# Altium schematic sheet MCU.SchDoc
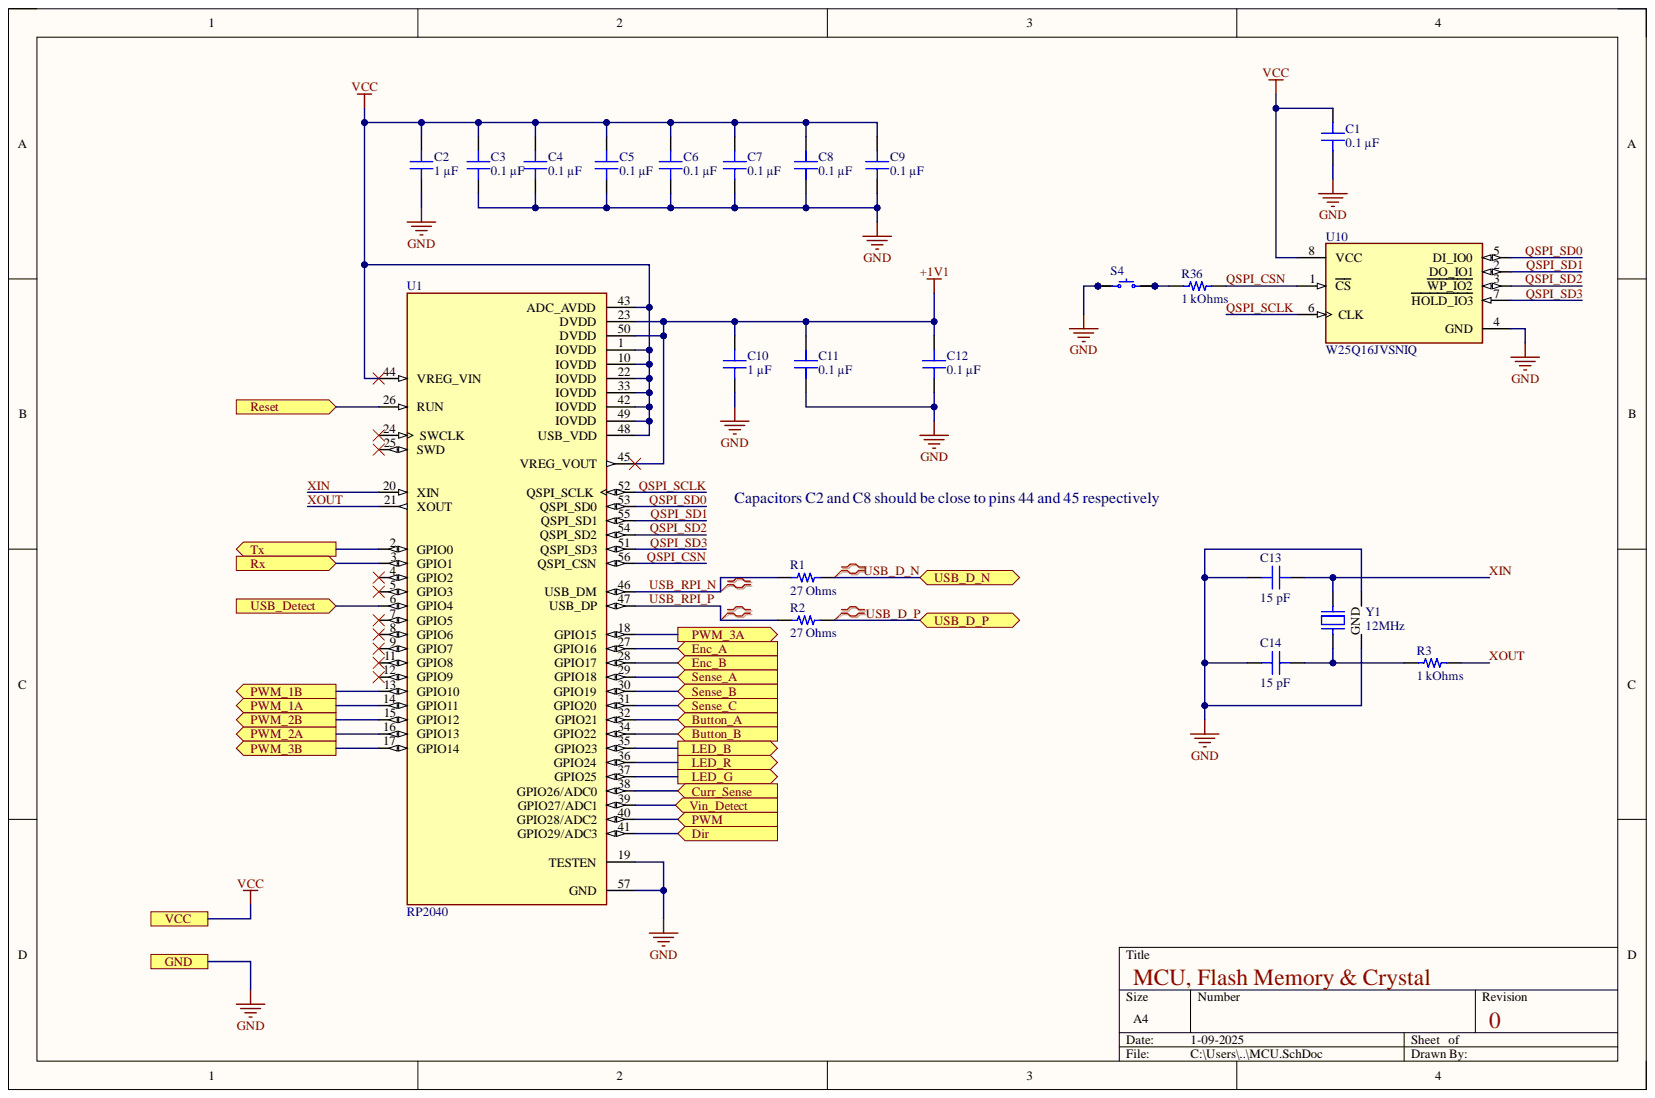
[diagram p0: MCU.SchDoc]

## Parts
C1  0.1 µF  [CAP 0201_0603]
C2  1 µF  [CAP 0201_0603]
C3  0.1 µF  [CAP 0201_0603]
C4  0.1 µF  [CAP 0201_0603]
C5  0.1 µF  [CAP 0201_0603]
C6  0.1 µF  [CAP 0201_0603]
C7  0.1 µF  [CAP 0201_0603]
C8  0.1 µF  [CAP 0201_0603]
C9  0.1 µF  [CAP 0201_0603]
C10  1 µF  [CAP 0201_0603]
C11  0.1 µF  [CAP 0201_0603]
C12  0.1 µF  [CAP 0201_0603]
C13  15 pF  [CAP 0201_0603]
C14  15 pF  [CAP 0201_0603]
R1  27 Ohms  [YAGEO RES 0201_0603]
R2  27 Ohms  [YAGEO RES 0201_0603]
R3  1 kOhms  [YAGEO RES 0201_0603]
R36  1 kOhms  [YAGEO RES 0201_0603]
S4  KMR741NG ULC LFS  [C&K KMR7]  (value not drawn)
U1  RP2040  [QFN40P700X700X90-57N]
U10  W25Q16JVSNIQ  [FP-W25Q16JVSNIQ_TR-IPC_B]
Y1  12MHz  [ABRACON ABM8G]

## Ports
Button_A, Button_B, Curr_Sense, Dir, Enc_A, Enc_B, GND, LED_B, LED_G, LED_R, PWM, PWM_1A, PWM_1B, PWM_2A, PWM_2B, PWM_3A, PWM_3B, Reset, Rx, Sense_A, Sense_B, Sense_C, Tx, USB_D_N, USB_D_P, USB_Detect, VCC, Vin_Detect

## Nets
+1V1: C10.2 C11.2 C12.2 U1.23(DVDD) U1.45(VREG_VOUT) U1.50(DVDD)
Button_A: U1.32(GPIO21) ; port Button_A
Button_B: U1.34(GPIO22) ; port Button_B
Curr_Sense: U1.38(GPIO26/ADC0) ; port Curr_Sense
Dir: U1.41(GPIO29/ADC3) ; port Dir
Enc_A: U1.27(GPIO16) ; port Enc_A
Enc_B: U1.28(GPIO17) ; port Enc_B
GND: C1.1 C2.1 C3.1 C4.1 C5.1 C6.1 C7.1 C8.1 C9.1 C10.1 C11.1 C12.1 C13.1 C14.1 S4.1 S4.2 U1.19(TESTEN) U1.57(GND) U10.4(GND) Y1.2 Y1.4 ; port GND
LED_B: U1.35(GPIO23) ; port LED_B
LED_G: U1.37(GPIO25) ; port LED_G
LED_R: U1.36(GPIO24) ; port LED_R
PWM: U1.40(GPIO28/ADC2) ; port PWM
PWM_1A: U1.14(GPIO11) ; port PWM_1A
PWM_1B: U1.13(GPIO10) ; port PWM_1B
PWM_2A: U1.16(GPIO13) ; port PWM_2A
PWM_2B: U1.15(GPIO12) ; port PWM_2B
PWM_3A: U1.18(GPIO15) ; port PWM_3A
PWM_3B: U1.17(GPIO14) ; port PWM_3B
QSPI_CSN: R36.1 U1.56(QSPI_CSN) U10.1(CS)
QSPI_SCLK: U1.52(QSPI_SCLK) U10.6(CLK)
QSPI_SD0: U1.53(QSPI_SD0) U10.5(DI_IO0)
QSPI_SD1: U1.55(QSPI_SD1) U10.2(DO_IO1)
QSPI_SD2: U1.54(QSPI_SD2) U10.3(WP_IO2)
QSPI_SD3: U1.51(QSPI_SD3) U10.7(HOLD_IO3)
Reset: U1.26(RUN) ; port Reset
Rx: U1.3(GPIO1) ; port Rx
Sense_A: U1.29(GPIO18) ; port Sense_A
Sense_B: U1.30(GPIO19) ; port Sense_B
Sense_C: U1.31(GPIO20) ; port Sense_C
Tx: U1.2(GPIO0) ; port Tx
USB_D_N: R1.2 ; port USB_D_N
USB_D_P: R2.2 ; port USB_D_P
USB_Detect: U1.6(GPIO4) ; port USB_Detect
USB_RPI_N: R1.1 U1.46(USB_DM)
USB_RPI_P: R2.1 U1.47(USB_DP)
VCC: C1.2 C2.2 C3.2 C4.2 C5.2 C6.2 C7.2 C8.2 C9.2 U1.1(IOVDD) U1.10(IOVDD) U1.22(IOVDD) U1.33(IOVDD) U1.42(IOVDD) U1.43(ADC_AVDD) U1.44(VREG_VIN) U1.48(USB_VDD) U1.49(IOVDD) U10.8(VCC) ; port VCC
Vin_Detect: U1.39(GPIO27/ADC1) ; port Vin_Detect
XIN: C13.2 U1.20(XIN) Y1.3
XOUT: R3.2 U1.21(XOUT)
NetC14_2: C14.2 R3.1 Y1.1
NetR36_2: R36.2 S4.3 S4.4
NetU1_4: U1.4(GPIO2)
NetU1_5: U1.5(GPIO3)
NetU1_7: U1.7(GPIO5)
NetU1_8: U1.8(GPIO6)
NetU1_9: U1.9(GPIO7)
NetU1_11: U1.11(GPIO8)
NetU1_12: U1.12(GPIO9)
NetU1_24: U1.24(SWCLK)
NetU1_25: U1.25(SWD)

## Library data of the parts
C1 C3-C9 C11 C12 = 885012104003: CAP CER 0.1UF 6.3V X5R 0201; manufacturer: Würth Elektronik; Database Table Name: Capacitors - Ceramic - 0201; Tolerance: ±20%; Applications: General Purpose; Capacitance: 0.1 µF; Component Kind: Standard; componentlink1description: Datasheet; componentlink1url: https://www.we-online.com/katalog/datasheet/885012104003.pdf; componentlink2description: DigiKey Link; componentlink2url: https://www.digikey.com/en/products/detail/würth-elektronik/885012104003/17734056; Device Package: 0201 (0603 Metric); lastupdated: 04-06-2024 19:00:59; Library Name: Celestial Altium Library - altium_library.DbLib; Lifecycle Status: Active; Minimum Order: 1; Mounting Type: Surface Mount, MLCC; Operating Temperature: -55°C ~ 85°C; Package / Case: 0201 (0603 Metric); packaging: TapeAndReel; Part Number: 885012104003; Part Status: Active; partid: 47467; price: 0.01; series: WCAP-CSGP; Size / Dimension: 0.024" L x 0.012" W (0.60mm x 0.30mm); Supplier 1: DigiKey; Supplier Part Number 1: 732-885012104003CT-ND; Temperature Coefficient: X5R; Thickness (Max): 0.013" (0.33mm); Value: 0.1 µF; Voltage - Rated: 6.3V
C2 C10 = 885012104011: CAP CER 1UF 6.3V X5R 0201; manufacturer: Würth Elektronik; Database Table Name: Capacitors - Ceramic - 0201; Tolerance: ±20%; Applications: General Purpose; Capacitance: 1 µF; Component Kind: Standard; componentlink1description: Datasheet; componentlink1url: https://www.we-online.com/katalog/datasheet/885012104011.pdf; componentlink2description: DigiKey Link; componentlink2url: https://www.digikey.com/en/products/detail/würth-elektronik/885012104011/17734031; Device Package: 0201 (0603 Metric); lastupdated: 04-06-2024 19:00:59; Library Name: Celestial Altium Library - altium_library.DbLib; Lifecycle Status: Active; Minimum Order: 1; Mounting Type: Surface Mount, MLCC; Operating Temperature: -55°C ~ 85°C; Package / Case: 0201 (0603 Metric); packaging: TapeAndReel; Part Number: 885012104011; Part Status: Active; partid: 47474; price: 0.01; series: WCAP-CSGP; Size / Dimension: 0.024" L x 0.012" W (0.60mm x 0.30mm); Supplier 1: DigiKey; Supplier Part Number 1: 732-885012104011CT-ND; Temperature Coefficient: X5R; Thickness (Max): 0.015" (0.39mm); Value: 1 µF; Voltage - Rated: 6.3V
C13 C14 = 885012004006: CAP CER 15PF 25V C0G/NP0 0201; manufacturer: Würth Elektronik; Database Table Name: Capacitors - Ceramic - 0201; Temperature Coefficient: C0G, NP0; Tolerance: ±5%; Applications: General Purpose; Capacitance: 15 pF; Component Kind: Standard; componentlink1description: Datasheet; componentlink1url: https://www.we-online.com/katalog/datasheet/885012004006.pdf; componentlink2description: DigiKey Link; componentlink2url: https://www.digikey.com/en/products/detail/würth-elektronik/885012004006/17734188; Device Package: 0201 (0603 Metric); lastupdated: 04-06-2024 19:00:59; Library Name: Celestial Altium Library - altium_library.DbLib; Lifecycle Status: Active; Minimum Order: 1; Mounting Type: Surface Mount, MLCC; Operating Temperature: -55°C ~ 125°C; Package / Case: 0201 (0603 Metric); packaging: TapeAndReel; Part Number: 885012004006; Part Status: Active; partid: 47479; price: 0.01; series: WCAP-CSGP; Size / Dimension: 0.024" L x 0.012" W (0.60mm x 0.30mm); Supplier 1: DigiKey; Supplier Part Number 1: 732-885012004006CT-ND; Thickness (Max): 0.013" (0.33mm); Value: 15 pF; Voltage - Rated: 25V
R1 R2 = RC0201FR-0727RL: RES 27 OHM 1% 1/20W 0201; Manufacturer: Yageo; Database Table Name: Resistors - Surface Mount - 0201; Power (Watts): 0.05W, 1/20W; Temperature Coefficient: ±200ppm/°C; Component Kind: Standard; ComponentLink1Description: Datasheet; ComponentLink1URL: https://www.yageo.com/upload/media/product/productsearch/datasheet/rchip/PYu-RC_Group_51_RoHS_L_10.pdf; ComponentLink2Description: DigiKey Link; ComponentLink2URL: https://www.digikey.com/en/products/detail/yageo/RC0201FR-0727RL/5280414; Composition: Thick Film; Device Package: 0201 (0603 Metric); Features: Moisture Resistant; Height - Seated (Max): 0.010" (0.26mm); LastUpdated: 25-07-2024 09:16:22; Library Name: Celestial Altium Library - altium_library.DbLib; Lifecycle Status: Active; Minimum Order: 1; Number of Terminations: 2; Operating Temperature: -55°C ~ 125°C; Package / Case: 0201 (0603 Metric); Packaging: TapeAndReel; Part Number: RC0201FR-0727RL; Part Status: Active; PartId: 3612; Price: 0.01; Resistance: 27 Ohms; Series: RC_L; Size / Dimension: 0.024" L x 0.012" W (0.60mm x 0.30mm); Supplier 1: DigiKey; Supplier Device Package: 0201; Supplier Part Number 1: YAG2554CT-ND; Tolerance: ±1%; Value: 27 Ohms
R3 = RC0201FR-131KL: RES 1K OHM 1% 1/20W 0201; Manufacturer: Yageo; Database Table Name: Resistors - Surface Mount - 0201; Power (Watts): 0.05W, 1/20W; Temperature Coefficient: ±200ppm/°C; Component Kind: Standard; ComponentLink1Description: Datasheet; ComponentLink1URL: https://www.yageo.com/upload/media/product/productsearch/datasheet/rchip/PYu-RC_Group_51_RoHS_L_12.pdf; ComponentLink2Description: DigiKey Link; ComponentLink2URL: https://www.digikey.com/en/products/detail/yageo/RC0201FR-131KL/17011251; Composition: Thick Film; Device Package: 0201 (0603 Metric); Features: Moisture Resistant; Height - Seated (Max): 0.010" (0.26mm); LastUpdated: 25-07-2024 09:16:19; Library Name: Celestial Altium Library - altium_library.DbLib; Lifecycle Status: Active; Minimum Order: 1; Number of Terminations: 2; Operating Temperature: -55°C ~ 125°C; Package / Case: 0201 (0603 Metric); Packaging: CutTape; Part Number: RC0201FR-131KL; Part Status: Active; PartId: 77423; Price: 0.01; Resistance: 1 kOhms; Series: RC_L; Size / Dimension: 0.024" L x 0.012" W (0.60mm x 0.30mm); Supplier 1: DigiKey; Supplier Device Package: 0201; Supplier Part Number 1: 13-RC0201FR-131KLCT-ND; Tolerance: ±1%; Value: 1 kOhms
R36 = RC0201JR-071KP: RES 1K OHM 5% 1/20W 0201; Manufacturer: Yageo; Database Table Name: Resistors - Surface Mount - 0201; Power (Watts): 0.05W, 1/20W; Ratings: 7C1 RoHS w/out Exemption (100% Pb-Free); Temperature Coefficient: ±200ppm/°C; Component Kind: Standard; ComponentLink1Description: Datasheet; ComponentLink1URL: https://www.yageo.com/upload/media/product/productsearch/datasheet/rchip/PYu-RC_51_RoHS_P_5.pdf; ComponentLink2Description: DigiKey Link; ComponentLink2URL: https://www.digikey.com/en/products/detail/yageo/RC0201JR-071KP/17010135; Composition: Thick Film; Device Package: 0201 (0603 Metric); Height - Seated (Max): 0.010" (0.26mm); LastUpdated: 25-07-2024 09:16:16; Library Name: Celestial Altium Library - altium_library.DbLib; Lifecycle Status: Active; Minimum Order: 1; Number of Terminations: 2; Operating Temperature: -55°C ~ 125°C; Package / Case: 0201 (0603 Metric); Packaging: TapeAndReel; Part Number: RC0201JR-071KP; PartId: 84954; Price: 0.01; Resistance: 1 kOhms; Series: RC_P; Size / Dimension: 0.024" L x 0.012" W (0.60mm x 0.30mm); Supplier 1: DigiKey; Supplier Device Package: 0201; Supplier Part Number 1: 13-RC0201JR-071KPCT-ND; Tolerance: ±5%; Value: 1 kOhms
S4 = KMR741NG ULC LFS: SWITCH TACTILE SPST-NO 50MA 32V; Manufacturer: C&K; Actuator Height off PCB, Vertical: 2.50mm; Actuator Orientation: Top Actuated; Actuator Type: Standard; Contact Rating @ Voltage: 0.05A @ 32VDC; Database Table Name: Switches - Tactile; Illumination: Non-Illuminated; Ingress Protection: IP67 - Dust Tight, Waterproof; Operating Force: 400gf; Outline: 4.20mm x 2.80mm; Switch Function: Off-Mom; Circuit: SPST-NO; Component Kind: Standard; ComponentLink1Description: Datasheet; ComponentLink1URL: https://dznh3ojzb2azq.cloudfront.net/products/Tactile/KMR7/documents/datasheet.pdf; ComponentLink2Description: DigiKey Link; ComponentLink2URL: http://digikey.com/product-detail/en/c-k/KMR741NG-ULC-LFS/CKN10688CT-ND/6035360; LastUpdated: 09-04-2020 10:01:05; Library Name: Celestial Altium Library - altium_library.DbLib; Lifecycle Status: Active; Minimum Order: 1; Mounting Type: Surface Mount; Operating Temperature: -40°C ~ 85°C; Packaging: TapeAndReel; Part Number: KMR741NG ULC LFS; Part Status: Active; Price: 0.16; Series: KMR7; Supplier 1: DigiKey; Supplier Part Number 1: CKN10688CT-ND; Termination Style: Gull Wing
U1 = CMP-324-000000-2: ARM Microcontrollers - MCU IC RP2040 (RP2B2) T&R, 500 (7" reel); MF: Raspberry Pi; Availability: In Stock; Check_prices: https://www.snapeda.com/parts/RP2040/Raspberry+Pi/view-part/?ref=eda; Component Kind: Standard; Component Type: Standard; Design Item ID: RP2040; Library Name: CSD Robocon Workspace; MP: RP2040; Package: None; Pin Count: 57; Price: None; Purchase-URL: https://pricing.snapeda.com/search/part/RP2040/?ref=eda; SnapEDA_Link: https://www.snapeda.com/parts/RP2040/Raspberry+Pi/view-part/?ref=snap; Source: RP2040.SchLib
U10 = CMP-00053-1: NOR Flash Serial (SPI, Dual SPI, Quad SPI) 3V/3.3V 16M-bit 2M x 8 6ns Automotive 8-Pin SOIC N T/R; Access Time: 5ms; Frequency: 133MHz; Max Supply Voltage: 3.6V; Memory Format: Non-Volatile,FLASH; Memory Size: 16Mb; Min Supply Voltage: 2.7V; Case/Package: SOIC; Component Kind: Standard; Height: 1.75mm; Interface: SPI; Library Name: CSD Robocon Workspace; Max Operating Temperature: 85°C; Min Operating Temperature: -40°C; Mounting Technology: Surface Mount; Packaging: Tape and Reel; Series: SpiFlash®
Y1 = ABM8G-12.000MHZ-B4Y-T: CRYSTAL 12.0000MHZ 10PF SMD; Manufacturer: Abracon LLC; Database Table Name: Oscillators - Crystals; ESR (Equivalent Series Resistance): 120 Ohms; Frequency Stability: ±30ppm; Operating Mode: Fundamental; Component Kind: Standard; ComponentLink1Description: Datasheet; ComponentLink1URL: https://abracon.com/Resonators/ABM8G.pdf; ComponentLink2Description: DigiKey Link; ComponentLink2URL: http://digikey.com/product-detail/en/abracon-llc/ABM8G-12.000MHZ-B4Y-T/535-10283-1-ND/2218096; Device Package: 4-SMD, No Lead; Frequency: 12MHz; Frequency Tolerance: ±30ppm; Height - Seated (Max): 0.039" (1.00mm); LastUpdated: 19-03-2020 22:36:25; Library Name: Celestial Altium Library - altium_library.DbLib; Lifecycle Status: Active; Load Capacitance: 10pF; Minimum Order: 1; Mounting Type: Surface Mount; Operating Temperature: -20°C ~ 70°C; Package / Case: 4-SMD, No Lead; Packaging: TapeAndReel; Part Number: ABM8G-12.000MHZ-B4Y-T; Part Status: Active; PartId: 301; Price: 0.50; Series: ABM8G; Size / Dimension: 0.126" L x 0.098" W (3.20mm x 2.50mm); Supplier 1: DigiKey; Supplier Part Number 1: 535-10283-1-ND; Type: MHz Crystal; Value: 12MHz
